# Altium schematic sheet Power.SCHDOC(Power)
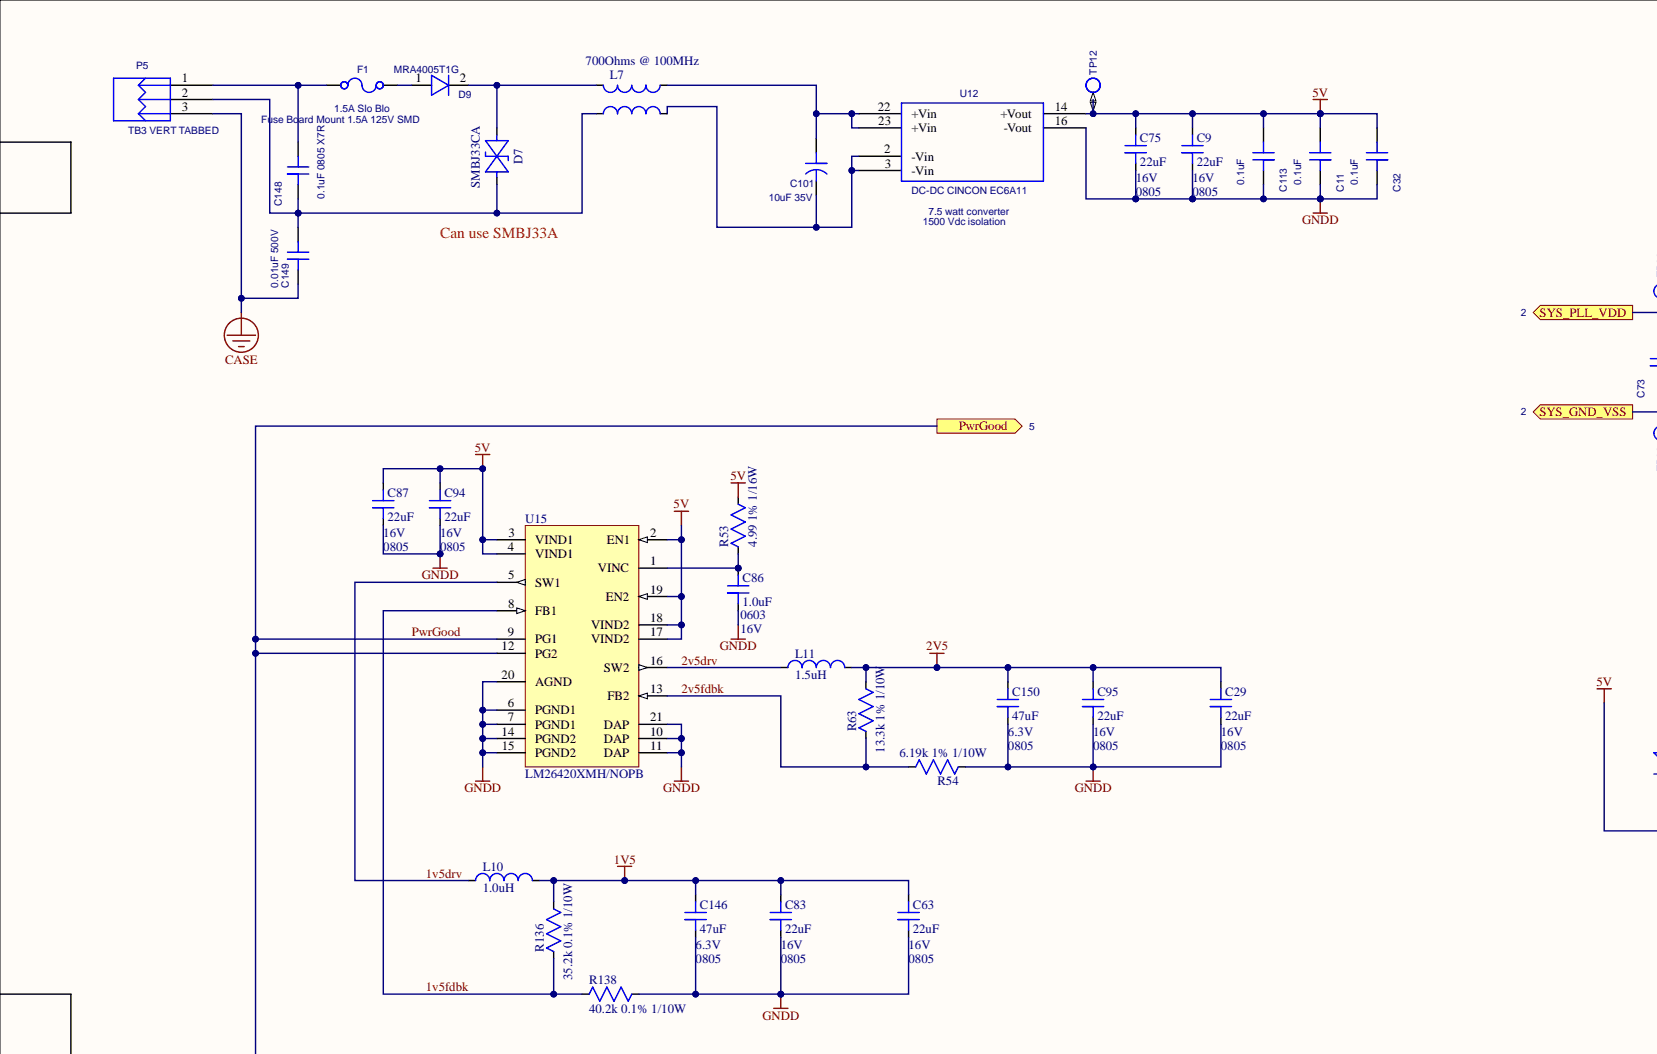
[diagram p0: Power.SCHDOC, top left]
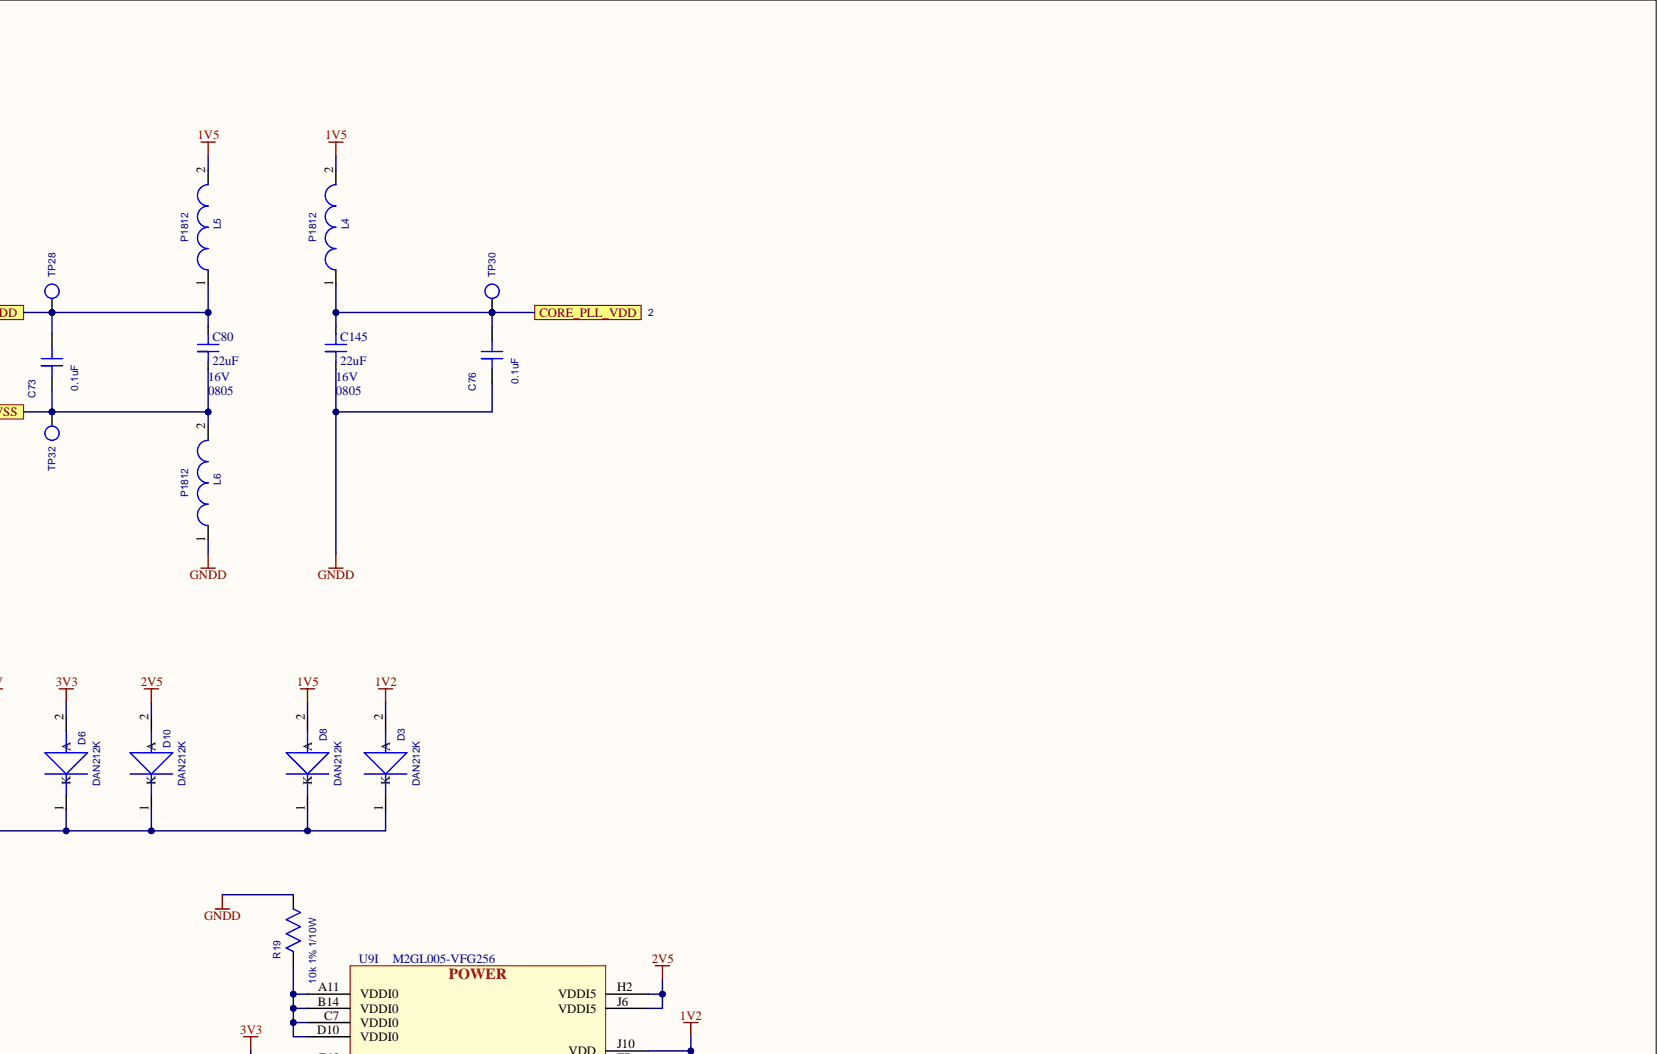
[diagram p1: Power.SCHDOC, top right]
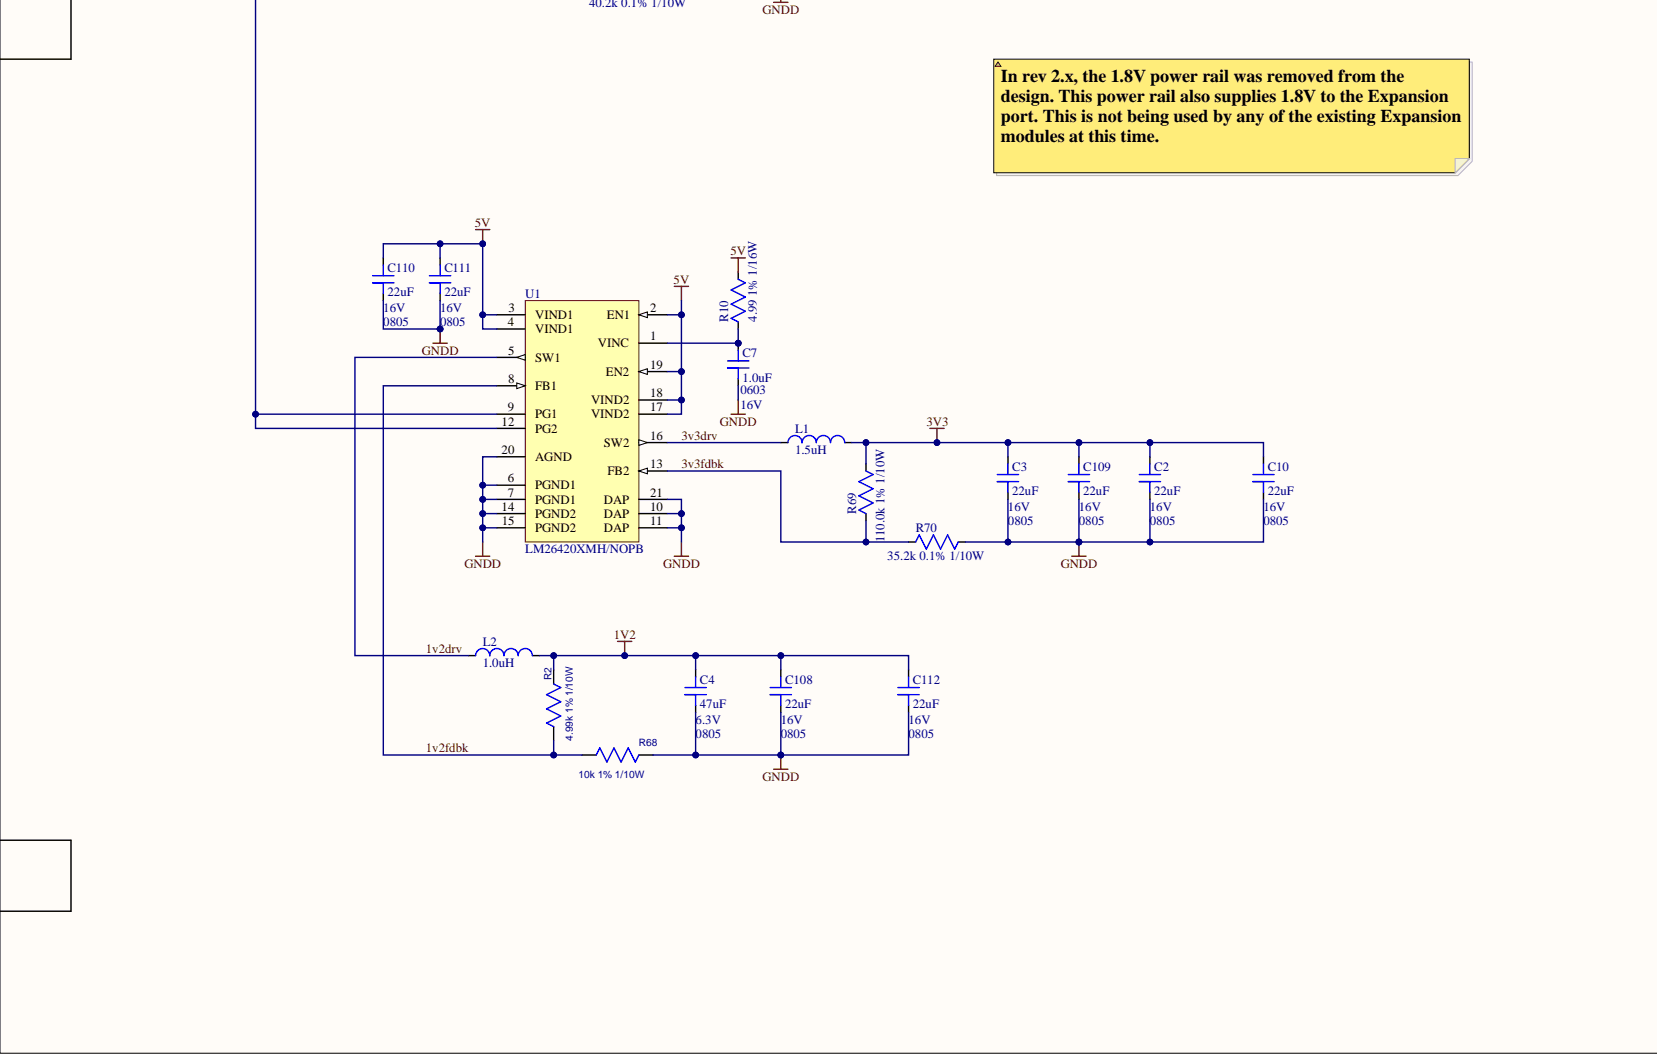
[diagram p2: Power.SCHDOC, bottom left]
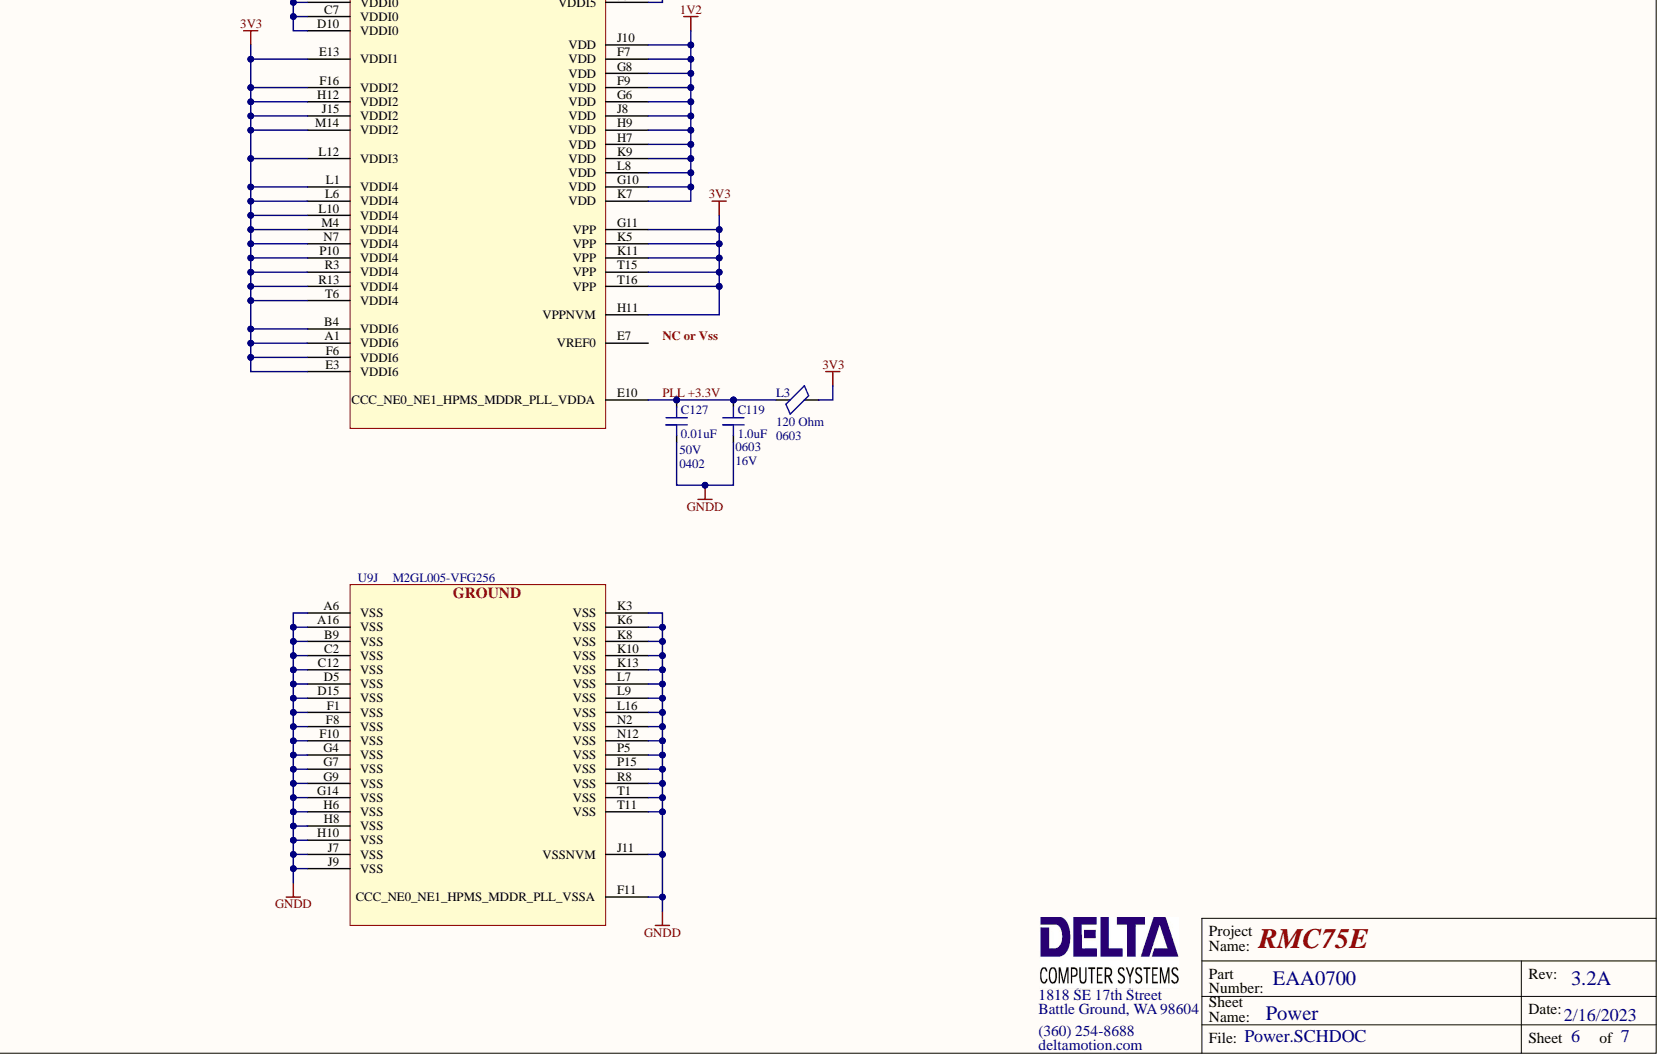
[diagram p3: Power.SCHDOC, bottom right]

## Parts
C2  22uF  CaseSize: 0805  RatedVoltage: 16V  [CAPC2012-N [0805]]
C3  22uF  CaseSize: 0805  RatedVoltage: 16V  [CAPC2012-N [0805]]
C4  47uF  CaseSize: 0805  RatedVoltage: 6.3V  [CAPC2012-N [0805]]
C7  1.0uF  CaseSize: 0603  RatedVoltage: 16V  [CAPC1608-N [0603]]
C9  22uF  CaseSize: 0805  RatedVoltage: 16V  [CAPC2012-N [0805]]
C10  22uF  CaseSize: 0805  RatedVoltage: 16V  [CAPC2012-N [0805]]
C11  0.1uF  [CC0603]
C29  22uF  CaseSize: 0805  RatedVoltage: 16V  [CAPC2012-N [0805]]
C32  0.1uF  [CC0603]
C63  22uF  CaseSize: 0805  RatedVoltage: 16V  [CAPC2012-N [0805]]
C73  0.1uF  [CC0603]
C75  22uF  CaseSize: 0805  RatedVoltage: 16V  [CAPC2012-N [0805]]
C76  0.1uF  [CC0603]
C80  22uF  CaseSize: 0805  RatedVoltage: 16V  [CAPC2012-N [0805]]
C83  22uF  CaseSize: 0805  RatedVoltage: 16V  [CAPC2012-N [0805]]
C86  1.0uF  CaseSize: 0603  RatedVoltage: 16V  [CAPC1608-N [0603]]
C87  22uF  CaseSize: 0805  RatedVoltage: 16V  [CAPC2012-N [0805]]
C94  22uF  CaseSize: 0805  RatedVoltage: 16V  [CAPC2012-N [0805]]
C95  22uF  CaseSize: 0805  RatedVoltage: 16V  [CAPC2012-N [0805]]
C101  10uF 35V  [CASE D POL]
C108  22uF  CaseSize: 0805  RatedVoltage: 16V  [CAPC2012-N [0805]]
C109  22uF  CaseSize: 0805  RatedVoltage: 16V  [CAPC2012-N [0805]]
C110  22uF  CaseSize: 0805  RatedVoltage: 16V  [CAPC2012-N [0805]]
C111  22uF  CaseSize: 0805  RatedVoltage: 16V  [CAPC2012-N [0805]]
C112  22uF  CaseSize: 0805  RatedVoltage: 16V  [CAPC2012-N [0805]]
C113  0.1uF  [CC0603]
C119  1.0uF  CaseSize: 0603  RatedVoltage: 16V  [CAPC1608-N [0603]]
C127  0.01uF  CaseSize: 0402  RatedVoltage: 50V  [CAPC1005-N [0402]]
C145  22uF  CaseSize: 0805  RatedVoltage: 16V  [CAPC2012-N [0805]]
C146  47uF  CaseSize: 0805  RatedVoltage: 6.3V  [CAPC2012-N [0805]]
C148  0.1uF 0805 X7R  [CC0805]
C149  0.01uF 500V  [CC1210]
C150  47uF  CaseSize: 0805  RatedVoltage: 6.3V  [CAPC2012-N [0805]]
D3  DAN212K  [SOT23_DAN212K(primary)]
D6  DAN212K  [SOT23_DAN212K(primary)]
D7  SMBJ33CA  Notes: Can use SMBJ33A  [SMB [DO-214AA]]
D8  DAN212K  [SOT23_DAN212K(primary)]
D9  MRA4005T1G  [U1-DIODE-T]
D10  DAN212K  [SOT23_DAN212K(primary)]
F1  Fuse Board Mount 1.5A 125V SMD  value 1.5A Slo Blo  [NANO SLOBLO 452/4]
L1  1.5uH  [IND [COILCRAFT XFL4020]]
L2  1.0uH  [IND [COILCRAFT XFL4020]]
L3  120 Ohm  Package: 0603  [INDC1608N [0603]]
L4  P1812  [1812]
L5  P1812  [1812]
L6  P1812  [1812]
L7  700Ohms @ 100MHz  [SOJ350P900X700-4M]
L10  1.0uH  [IND [COILCRAFT XFL4020]]
L11  1.5uH  [IND [COILCRAFT XFL4020]]
P5  TB3 VERT TABBED  [TB3VERT TABS]
R2  4.99k 1% 1/10W  [CC0603]
R10  4.99 1% 1/16W  [RESC1608-N [0603]]
R19  10k 1% 1/10W  [CC0603]
R53  4.99 1% 1/16W  [RESC1608-N [0603]]
R54  6.19k 1% 1/10W  PowerRating: 1/10W  [RESC2012-N [0805]]
R63  13.3k 1% 1/10W  PowerRating: 1/10W  [RESC2012-N [0805]]
R68  10k 1% 1/10W  [CC0603]
R69  110.0k 1% 1/10W  PowerRating: 1/10W
R70  35.2k 0.1% 1/10W  PowerRating: 1/10W
R136  35.2k 0.1% 1/10W  PowerRating: 1/10W
R138  40.2k 0.1% 1/10W  [RESC1608-N [0603]]
TP12  TP1  [TESTP_XY60D40]  (no BOM)
TP28  TP_RND_SMT  [TP_SMT_RND]  (value not drawn)  (no BOM)
TP30  TP_RND_SMT  [TP_SMT_RND]  (value not drawn)  (no BOM)
TP32  TP_RND_SMT  [TP_SMT_RND]  (value not drawn)  (no BOM)
U1  LM26420XMH/NOPB  [MXA20A_M]
U9  M2GL005-VFG256  [BGA256C80P16X16_1410X1410X156]  (parts I,J)
U12  DC-DC CINCON EC6A11  PartNumber: EC6A11  [DC-DC 24P DIP_DC-DC CINCON EC6A11(Primary)]
U15  LM26420XMH/NOPB  [MXA20A_M]

## Ports
CORE_PLL_VDD, PwrGood, SYS_GND_VSS, SYS_PLL_VDD

## Nets
1V5: C63.1 C83.1 C146.1 D8.2 L4.2 L5.2 L10.2 R136.2
5V: C9.1 C11.1 C32.1 C75.1 C87.1 C94.1 C110.1 C111.1 C113.1 D3.1 D6.1 D8.1 D10.1 R10.2 R53.2 TP12.1 U1.2(EN1) U1.3(VIND1) U1.4(VIND1) U1.17(VIND2) U1.18(VIND2) U1.19(EN2) U12.14(+Vout) U15.2(EN1) U15.3(VIND1) U15.4(VIND1) U15.17(VIND2) U15.18(VIND2) U15.19(EN2)
CASE: C149.2 P5.3
GNDD: C2.2 C3.2 C4.2 C7.2 C9.2 C10.2 C11.2 C29.2 C32.2 C63.2 C75.2 C76.2 C83.2 C86.2 C87.2 C94.2 C95.2 C108.2 C109.2 C110.2 C111.2 C112.2 C113.2 C119.2 C127.2 C145.2 C146.2 C150.2 L6.1 R19.2 R54.1 R68.2 R70.2 R138.2 U1.6(PGND1) U1.7(PGND1) U1.10(DAP) U1.11(DAP) U1.14(PGND2) U1.15(PGND2) U1.20(AGND) U1.21(DAP) U9.A6(VSS) U9.A16(VSS) U9.B9(VSS) U9.C2(VSS) U9.C12(VSS) U9.D5(VSS) U9.D15(VSS) U9.F1(VSS) U9.F8(VSS) U9.F10(VSS) U9.F11(CCC_NE0_NE1_HPMS_MDDR_PLL_VSSA) U9.G4(VSS) U9.G7(VSS) U9.G9(VSS) U9.G14(VSS) U9.H6(VSS) U9.H8(VSS) U9.H10(VSS) U9.J7(VSS) U9.J9(VSS) U9.J11(VSSNVM) U9.K3(VSS) U9.K6(VSS) U9.K8(VSS) U9.K10(VSS) U9.K13(VSS) U9.L7(VSS) U9.L9(VSS) U9.L16(VSS) U9.N2(VSS) U9.N12(VSS) U9.P5(VSS) U9.P15(VSS) U9.R8(VSS) U9.T1(VSS) U9.T11(VSS) U12.16(-Vout) U15.6(PGND1) U15.7(PGND1) U15.10(DAP) U15.11(DAP) U15.14(PGND2) U15.15(PGND2) U15.20(AGND) U15.21(DAP)
1V2: C4.1 C108.1 C112.1 D3.2 L2.1 L2.2 L10.1 R2.1 R2.2 R68.1 R136.1 R138.1 U1.5(SW1) U1.8(FB1) U9.F7(VDD) U9.F9(VDD) U9.G6(VDD) U9.G8(VDD) U9.G10(VDD) U9.H7(VDD) U9.H9(VDD) U9.J8(VDD) U9.J10(VDD) U9.K7(VDD) U9.K9(VDD) U9.L8(VDD) U15.5(SW1) U15.8(FB1)  [also labelled 1v2drv, 1v2fdbk, 1v5drv, 1v5fdbk]
2V5: C29.1 C95.1 C150.1 D10.2 L11.1 L11.2 R54.2 R63.1 R63.2 U9.H2(VDDI5) U9.J6(VDDI5) U15.13(FB2) U15.16(SW2)  [also labelled 2v5drv, 2v5fdbk]
3V3: C2.1 C3.1 C10.1 C109.1 D6.2 L1.1 L1.2 L3.2 R69.1 R69.2 R70.1 U1.13(FB2) U1.16(SW2) U9.A1(VDDI6) U9.B4(VDDI6) U9.E3(VDDI6) U9.E13(VDDI1) U9.F6(VDDI6) U9.F16(VDDI2) U9.G11(VPP) U9.H11(VPPNVM) U9.H12(VDDI2) U9.J15(VDDI2) U9.K5(VPP) U9.K11(VPP) U9.L1(VDDI4) U9.L6(VDDI4) U9.L10(VDDI4) U9.L12(VDDI3) U9.M4(VDDI4) U9.M14(VDDI2) U9.N7(VDDI4) U9.P10(VDDI4) U9.R3(VDDI4) U9.R13(VDDI4) U9.T6(VDDI4) U9.T15(VPP) U9.T16(VPP)  [also labelled 3v3drv, 3v3fdbk]
CORE_PLL_VDD: C76.1 C145.1 L4.1 TP30.1 ; port CORE_PLL_VDD
PLL +3.3V: C119.1 C127.1 L3.1 U9.E10(CCC_NE0_NE1_HPMS_MDDR_PLL_VDDA)
PwrGood: U1.9(PG1) U1.12(PG2) U15.9(PG1) U15.12(PG2) ; port PwrGood
SYS_GND_VSS: C73.2 C80.2 L6.2 TP32.1 ; port SYS_GND_VSS
SYS_PLL_VDD: C73.1 C80.1 L5.1 TP28.1 ; port SYS_PLL_VDD
NetC7_1: C7.1 R10.1 U1.1(VINC)
NetC86_1: C86.1 R53.1 U15.1(VINC)
NetC101_1: C101.1 L7.4 U12.22(+Vin) U12.23(+Vin)
NetC101_2: C101.2 L7.3 U12.2(-Vin) U12.3(-Vin)
NetC148_1: C148.1 F1.1 P5.1
NetC148_2: C148.2 C149.1 D7.2 L7.2 P5.2
NetD7_1: D7.1 D9.2 L7.1
NetD9_1: D9.1 F1.2
NetR19_1: R19.1 U9.A11(VDDI0) U9.B14(VDDI0) U9.C7(VDDI0) U9.D10(VDDI0)

Not connected: U9 E7(VREF0)

## Library data of the parts
C2 C3 C9 C10 C29 C63 C75 C80 C83 C87 C94 C95 C108-C112 C145 = Capacitor - Non-polarized: Cap Ceramic X5R 22uF 20% 16V 0805; Manufacturer: Venkel; ManufacturerAlt1: Various; PartNumber: C0805X5R160-226MNE; Component Kind: Standard; ComponentType: Capacitor; DateUpdated: 03/11/2022; Library Name: Capacitor.IntLib; PartNumberAlt1: Various; Tolerance: 20%; Type: Ceramic X5R; Value: 22uF
C4 C146 C150 = Capacitor - Non-polarized: Cap Ceramic X5R 47uF 20% 6.3V 0805; Manufacturer: Venkel; ManufacturerAlt1: Various; PartNumber: C0805X5R63-476MNE; Notes: ECA0096; Component Kind: Standard; ComponentType: Capacitor; DateUpdated: 05/23/2022; Library Name: Capacitor.IntLib; PartNumberAlt1: Various; Tolerance: 20%; Type: Ceramic X5R; Value: 47uF
C7 C86 C119 = Cap Generic Non-Polarized 0805: Cap Ceramic X7R 1.0uF 10% 16V 0603; Manufacturer: Venkel; PartNumber: C0603X7R160-105KNE; Database Table Name: CapacitorTable$; Component Kind: Standard; ComponentType: Capacitor; DateUpdated: 01/10/2022; Library Name: Capacitor.DbLib; Tolerance: 10%; Type: Ceramic X7R; Value: 1.0uF
C11 C32 C73 C76 C113 = CAPNP_SMT0603: Cap Cer 0.1uF 25V 10% 0603 X7R; Manufacturer: Venkel; PartNumber: C0603X7R250-104KNE; Component Kind: Standard; Value: 0.1uF
C101 = CAP POL CASE D: Cap Tant 10uF 35V 10% D; Manufacturer: Venkel; PartNumber: TA035TCM106KDR; Component Kind: Standard; Value: 10uF 35V
C127 = Capacitor - Non-polarized: Cap Cer 0.01uF 50V 10% 0402 X7R; Manufacturer: Venkel; ManufacturerAlt1: Various; PartNumber: C0402X7R500-103KNE; Type: Ceramic X7R; Component Kind: Standard; ComponentType: Capacitor; DateUpdated: 02/12/2021; Library Name: Capacitor.IntLib; PartNumberAlt1: Various; Tolerance: 10%; Value: 0.01uF
C148 = CAPNP_SMT0805: Cap Cer 0.1uF 100V 10% 0805 X7R; Manufacturer: Venkel; PartNumber: C0805X7R101-104KNP; Notes: ECA0147; Component Kind: Standard; Value: 0.1uF 0805 X7R
C149 = CAPNP_SMT1210: Cap Cer 0.01uF 500V 10% 1210 X7R; Manufacturer: Venkel; PartNumber: C1210X7R501-103KNE; Component Kind: Standard; Value: 0.01uF 500V
D3 D6 D8 D10 = DAN212K: Diode GP 80V 100mA SMD3; Manufacturer: Rohm; PartNumber: DAN212KT146; Component Kind: Standard
D7 = SMBJ150CA: Transient Voltage Suppression Diode 33V; Manufacturer: Littlefuse; ManufacturerAlt1: Bourns; BreakdownVoltMax: 40.6V; BreakdownVoltMin: 36.7V; MaxClampVolt: 53.3V; MaxPeakPulsCurr: 11.3A; TestCurrent: 1mA; Component Kind: Standard; ComponentType: Protection; DateUpdated: 02/16/2023; Library Name: Protection.IntLib; ManufacturerAlt2: Fairchild; PartNumber: SMBJ33CA; PartNumberAlt1: SMBJ33CA; PartNumberAlt2: SMBJ33CA
D9 = U1JC44-T: Diode GP 600V 1A PMDS; Manufacturer: Rohm; Notes: EDA0033; Component Kind: Standard; PartNumber: MRA4005T1G; Value: MRA4005T1G
F1 = NANO SLOBLO 452/454 SERIES: Manufacturer: Littelfuse; PartNumber: 045201.5MRL; Component Kind: Standard; Description: NANO SLOBLO 452/454 SERIES
L1 L11 = XFL4020: Inductor 1.5uH 10% 4A; Manufacturer: Coilcraft; PartNumber: XFL4020-152MEC; CurrentRMS: 8.0; CurrentSat: 4.1; ResistanceDC: 14.4 mOhms; Component Kind: Standard; ComponentType: Magnetic; DateUpdated: 11/17/2011; Tolerance: 10%; Value: 1.5uH; Library Name: Magnetic.IntLib
L2 L10 = XFL4020: Inductor 1.0uH 10% 4A; Manufacturer: Coilcraft; PartNumber: XFL4020-102MEC; CurrentRMS: 8.0; CurrentSat: 4.1; ResistanceDC: 10.8 mOhms; Component Kind: Standard; ComponentType: Magnetic; DateUpdated: 11/17/2011; Tolerance: 10%; Value: 1.0uH; Library Name: Magnetic.IntLib
L3 = Ferrite Bead: Ferrite Bead 120 Ohm 0603; Manufacturer: Murata; PartNumber: BLM18PG121SN1; DCResistance: 0.05; RatedCurrent: 2A; Component Kind: Standard; ComponentType: Magnetic; Dateupdated: 10/22/2010; Impedance: 120 Ohm; Library Name: Magnetic.IntLib
L4-L6 = P1812: Inductor Fixed 100uH 1801mA 4.32Ohm 1812; Manufacturer: API Delevan; PartNumber: P1812R-104K; Component Kind: Standard
L7 = ACM7060: Choke Common Mode 4A DCR 15mOhm 700ohm@100MHz; Manufacturer: TDK; PartNumber: ACM9070-701-2PL-TL01; ResistanceDC: 0.015 Ohms; Tolerance: 20%; Component Kind: Standard; ComponentType: Magnetic; CurrentRMS: 4 A; CurrentSat: 4 A; DateUpdated: 11/27/2013; Value: 700Ohms @ 100MHz; Library Name: Magnetic.IntLib
P5 = TB3 VERT TABBED: Manufacturer: Metz; PartNumber: 31235103; Component Kind: Standard; Description: TB3 VERT TABBED
R2 = RES_GEN_SMT0603: Res 4.99k 1% 1/10W 100ppm 0603; Manufacturer: Venkel; PartNumber: CR0603-10W-4991FT; Component Kind: Standard; Value: 4.99k 1% 1/10W
R10 R53 = Res Generic 0603: Res 4.99 1.0% 1/16W 0603; Manufacturer: Yageo; PartNumber: RC0603FR-074R99L; Database Table Name: ResistorTable$; CaseSize: 0603; Component Kind: Standard; ComponentType: Resistor; DateUpdated: 11/8/2010; Library Name: Resistor.DbLib; Tolerance: 1.0%; Value: 4.99 1% 1/16W
R19 R68 = RES_GEN_SMT0603: Res 10k 1% 1/10W 100ppm 0603; Manufacturer: Venkel; PartNumber: CR0603-10W-1002FT; Component Kind: Standard; Value: 10k 1% 1/10W
R54 = Res 6.19k 1.0% 1/10W 0805: Manufacturer: Venkel; ManufacturerAlt1: KOA Speer; PartNumber: CR0805-10W-6191FT; PartNumberAlt1: RK73H2ATTD6191F; Database Table Name: ResistorTable$; CaseSize: 0805; Component Kind: Standard; ComponentType: Resistor; DateUpdated: 11/8/2010; Description: Res 6.19k 1.0% 1/10W 0805; Library Name: Resistor.DbLib; Tolerance: 1.0%; Value: 6.19k 1% 1/10W
R63 = Res 13.3k 1.0% 1/10W 0805: Manufacturer: Venkel; ManufacturerAlt1: KOA Speer; PartNumber: CR0805-10W-13322FT; PartNumberAlt1: RK73H2ATTD1332F; Database Table Name: ResistorTable$; CaseSize: 0805; Component Kind: Standard; ComponentType: Resistor; DateUpdated: 11/8/2010; Description: Res 13.3k 1.0% 1/10W 0805; Library Name: Resistor.DbLib; Tolerance: 1.0%; Value: 13.3k 1% 1/10W
R69 = Res Generic 0603: Res 110.0k 1.0% 1/10W 0603; Manufacturer: Venkel; PartNumber: TFCR0603-10W-C-1103FT; Database Table Name: ResistorTable$; CaseSize: 0603; Component Kind: Standard; ComponentType: Resistor; DateUpdated: 11/8/2010; Library Name: Resistor.DbLib; Tolerance: 1.0%; Value: 110.0k 1% 1/10W
R70 R136 = Res Generic 0603: Res 35.2k 0.1% 1/10W 0603; Manufacturer: Venkel; PartNumber: TFCR0603-10W-C-3522BT; Database Table Name: ResistorTable$; Tolerance: 1.0%; CaseSize: 0603; Component Kind: Standard; ComponentType: Resistor; DateUpdated: 11/8/2010; Library Name: Resistor.DbLib; Value: 35.2k 0.1% 1/10W
R138 = Res 10.0k 1.0% 1/16W 0603: Res 40.2k 0.1% 1/10W 0603; Manufacturer: Venkel; PartNumber: TFCR0603-10W-C-4022BT; Database Table Name: ResistorTable$; PowerRating: 1/16W; Tolerance: 1.0%; CaseSize: 0603; Component Kind: Standard; ComponentType: Resistor; DateUpdated: 11/8/2010; Library Name: Resistor.DbLib; Value: 40.2k 0.1% 1/10W
TP12 = TP1: Value: 5V; Description: TP1
U1 U15 = CMP-0069-01644-2: Dual 2.0A, High Frequency Synchronous Step-Down DC-DC Regulator, 20-pin TSSOP-EP, Pb-Free; Manufacturer: National Semiconductor; PackageTopMarking: NSUZXYTT*LM26420*XMH; Component Kind: Standard; DatasheetVersion: May-2009; Library Name: Altium Content Vault; PackageDescription: Molded TSSOP, Jedec, 6.5 x 4.4 x 0.9mm Body, 20 Lead, 0.65mm Pitch, Exposed Pad; PackageReference: MXA20A; PackageVersion: revC, Jul-2006; PartNumber: LM26420XMH/NOPB; Type: Switcher
U9 = M2GL005-VFG265: Microchip Igloo2 FPGA 6060 LE 161 IO; Component Kind: Standard; ComponentType: ProgrammableLogic; DateUpdated: 04/19/2022; Manufacturer: Microchip; PartNumber: M2GL005-VFG256; Library Name: ProgrammableLogic.IntLib
U12 = DC-DC CINCON EC6A11: DC-DC Converter Iso 1.5A 24V-5V 7.5W; Component Kind: Standard; Manufacturer: Cincon
TP28 TP30 TP32 = TP_RND_SMT: Description: TP_RND_SMT
